# Altium schematic sheet ChinoRobocon.SchDoc
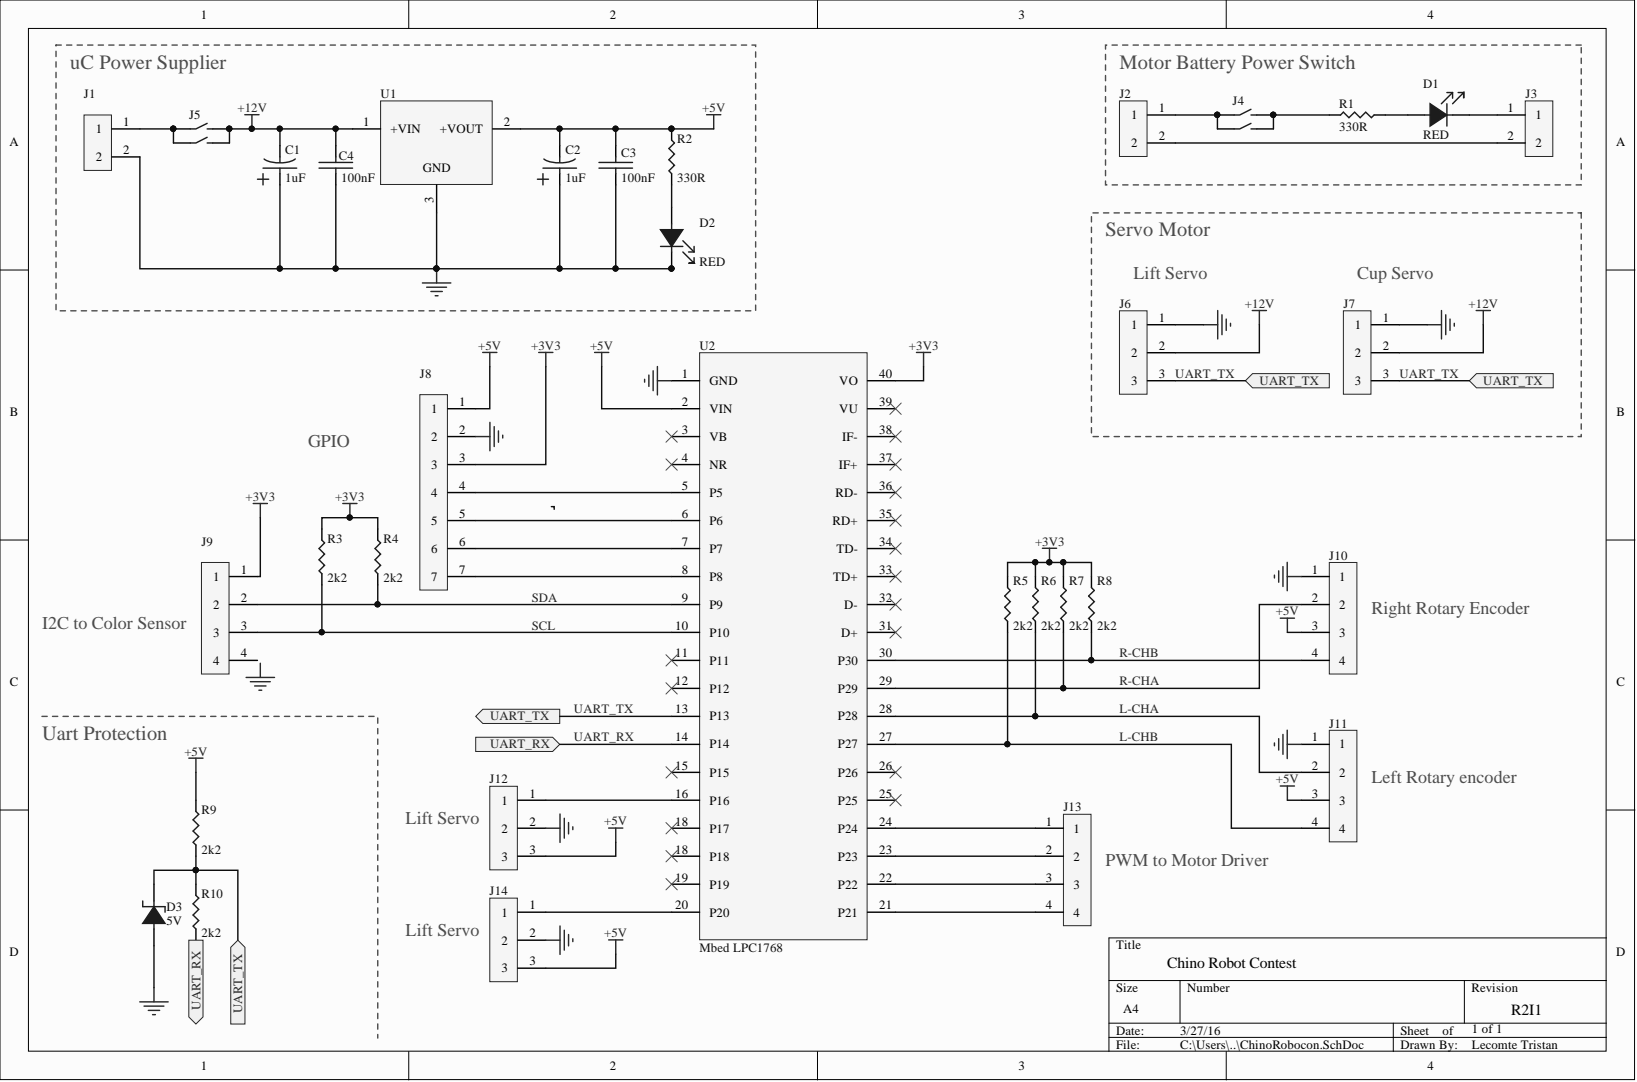
[diagram p0: ChinoRobocon.SchDoc]

## Parts
C1  1uF
C2  1uF
C3  100nF
C4  100nF
D1  RED
D2  RED
D3  5V
J1  2Pins Connector  (value not drawn)
J2  2Pins Connector  (value not drawn)
J3  2Pins Connector  (value not drawn)
J4  Switch  (value not drawn)
J5  Switch  (value not drawn)
J6  3Pins Connector  (value not drawn)
J7  3Pins Connector  (value not drawn)
J8  7Pins Connector  (value not drawn)
J9  4Pins Connector  (value not drawn)
J10  4Pins Connector  (value not drawn)
J11  4Pins Connector  (value not drawn)
J12  3Pins Connector  (value not drawn)
J13  4Pins Connector  (value not drawn)
J14  3Pins Connector  (value not drawn)
R1  330R
R2  330R
R3  2k2
R4  2k2
R5  2k2
R6  2k2
R7  2k2
R8  2k2
R9  2k2
R10  2k2
U1  DC/DC Converter  (value not drawn)
U2  Mbed LPC1768

## Ports
UART_RX, UART_TX

## Nets
+3V3: J8.3 J9.1 R3.1 R4.1 R5.1 R6.1 R7.1 R8.1 U2.40(VO)
+5V: C2.2 C3.2 J8.1 J10.3 J11.3 J12.3 J14.3 R2.1 R9.1 U1.2 U2.2(VIN)
+12V: C1.2 C4.2 J5.5 J5.6 J6.2 J7.2 U1.1
: C1.1 C2.1 C3.1 C4.1 D2.2 D3.1 J1.2 J6.1 J7.1 J8.2 J10.1 J11.1 J12.2 J14.2 U1.3 U2.1(GND)
L-CHA: J11.2 R6.2 U2.28(P28)
L-CHB: J11.4 R5.2 U2.27(P27)
R-CHA: J10.2 R7.2 U2.29(P29)
R-CHB: J10.4 R8.2 U2.30(P30)
SCL: J9.3 R3.2 U2.10(P10)
SDA: J9.2 R4.2 U2.9(P9)
UART_RX: R10.2 U2.14(P14) ; port UART_RX
UART_TX: D3.2 J6.3 J7.3 R9.2 R10.1 U2.13(P13) ; port UART_TX
NetD1_1: D1.1 R1.2
NetD1_2: D1.2 J3.1
NetD2_1: D2.1 R2.2
NetJ1_1: J1.1 J5.3 J5.4
NetJ2_1: J2.1 J4.3 J4.4
NetJ2_2: J2.2 J3.2
NetJ4_5: J4.5 J4.6 R1.1
NetJ8_4: J8.4 U2.5(P5)
NetJ8_5: J8.5 U2.6(P6)
NetJ8_6: J8.6 U2.7(P7)
NetJ8_7: J8.7 U2.8(P8)
NetJ12_1: J12.1 U2.16(P16)
NetJ13_1: J13.1 U2.24(P24)
NetJ13_2: J13.2 U2.23(P23)
NetJ13_3: J13.3 U2.22(P22)
NetJ13_4: J13.4 U2.21(P21)
NetJ14_1: J14.1 U2.20(P20)
N00007:

Not connected: J9 4; U2 3(VB) 4(NR) 11(P11) 12(P12) 15(P15) 18(P17) 19(P19) 25(P25) 26(P26) 31(D+) 32(D-) 33(TD+) 34(TD-) 35(RD+) 36(RD-) 37(IF+) 38(IF-) 39(VU)

## Library data of the parts
C1 C2 = CAP POL: Component Kind: Standard; Library Name: ChinoRobocon.SchLib
C3 C4 = CAP: Component Kind: Standard; Library Name: ChinoRobocon.SchLib
D1 D2 = LED: Component Kind: Standard; Library Name: ChinoRobocon.SchLib
D3 = Shockty diode: Component Kind: Standard; Library Name: ChinoRobocon.SchLib
R1-R10 = Resistor: Component Kind: Standard; Library Name: ChinoRobocon.SchLib
U2 = Mbed: Component Kind: Standard; Library Name: ChinoRobocon.SchLib
J1-J3 = 2Pins Connector: Component Kind: Standard; Library Name: ChinoRobocon.SchLib
J4 J5 = Switch: Component Kind: Standard; Library Name: ChinoRobocon.SchLib
J6 J7 J12 J14 = 3Pins Connector: Component Kind: Standard; Library Name: ChinoRobocon.SchLib
J8 = 7Pins Connector: Component Kind: Standard; Library Name: ChinoRobocon.SchLib
J9-J11 J13 = 4Pins Connector: Component Kind: Standard; Library Name: ChinoRobocon.SchLib
U1 = DC/DC Converter: Component Kind: Standard; Library Name: ChinoRobocon.SchLib
